# Altium schematic sheet DEBUGGER_R1.1.SchDoc
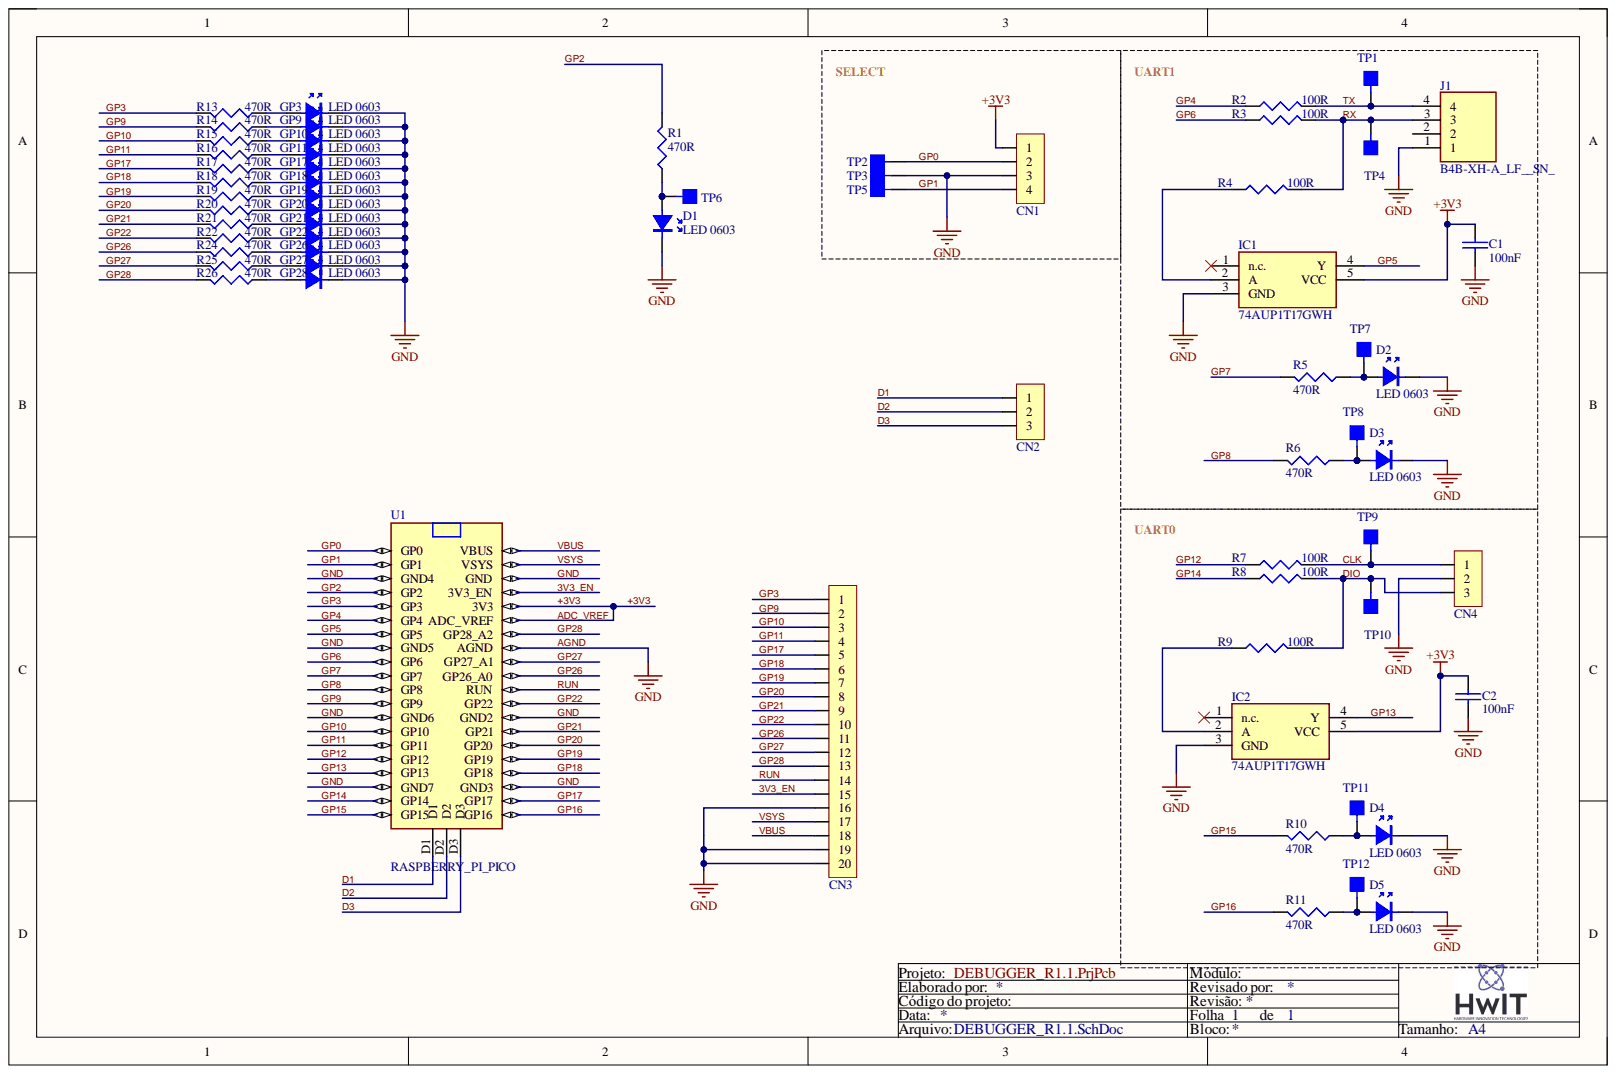
[diagram p0: DEBUGGER_R1.1.SchDoc]

## Parts
C1  100nF  [C0603]
C2  100nF  [C0603]
CN1  HD 1XV HOR  [HEADER4 VERT]  (value not drawn)
CN2  HD 1X3 HOR  [HEADER3 VERT]  (value not drawn)
CN3  HD 1X20 VERT  [HEADER 20 VERT 2.54mm]  (value not drawn)
CN4  HD 1X3 HOR  [HEADER3 VERT]  (value not drawn)
D1  LED 0603  [LED0603]
D2  LED 0603  [LED0603]
D3  LED 0603  [LED0603]
D4  LED 0603  [LED0603]
D5  LED 0603  [LED0603]
GP3  LED 0603  [LED0603]
GP9  LED 0603  [LED0603]
GP10  LED 0603  [LED0603]
GP11  LED 0603  [LED0603]
GP17  LED 0603  [LED0603]
GP18  LED 0603  [LED0603]
GP19  LED 0603  [LED0603]
GP20  LED 0603  [LED0603]
GP21  LED 0603  [LED0603]
GP22  LED 0603  [LED0603]
GP26  LED 0603  [LED0603]
GP27  LED 0603  [LED0603]
GP28  LED 0603  [LED0603]
IC1  74AUP1T17GWH  [SOT65P212X110-5N]
IC2  74AUP1T17GWH  [SOT65P212X110-5N]
J1  B4B-XH-A_LF__SN_  [B4BXHALFSN]
R1  470R  [R0603]
R2  100R  [R0603]
R3  100R  [R0603]
R4  100R  [R0603]
R5  470R  [R0603]
R6  470R  [R0603]
R7  100R  [R0603]
R8  100R  [R0603]
R9  100R  [R0603]
R10  470R  [R0603]
R11  470R  [R0603]
R13  470R  [R0603]
R14  470R  [R0603]
R15  470R  [R0603]
R16  470R  [R0603]
R17  470R  [R0603]
R18  470R  [R0603]
R19  470R  [R0603]
R20  470R  [R0603]
R21  470R  [R0603]
R22  470R  [R0603]
R24  470R  [R0603]
R25  470R  [R0603]
R26  470R  [R0603]
TP1  TP60  [TP60]  (value not drawn)  (no BOM)
TP2  TP60  [TP60]  (value not drawn)  (no BOM)
TP3  TP60  [TP60]  (value not drawn)  (no BOM)
TP4  TP60  [TP60]  (value not drawn)  (no BOM)
TP5  TP60  [TP60]  (value not drawn)  (no BOM)
TP6  TP60  [TP60]  (no BOM)
TP7  TP60  [TP60]  (value not drawn)  (no BOM)
TP8  TP60  [TP60]  (value not drawn)  (no BOM)
TP9  TP60  [TP60]  (value not drawn)  (no BOM)
TP10  TP60  [TP60]  (value not drawn)  (no BOM)
TP11  TP60  [TP60]  (value not drawn)  (no BOM)
TP12  TP60  [TP60]  (value not drawn)  (no BOM)
U1  RASPBERRY_PI_PICO  [SC0915_RPI]

## Nets
3V3_EN: C1.2 C2.2 CN1.1 CN3.15 IC1.5(VCC) IC2.5(VCC) U1.35(ADC_VREF) U1.36(3V3) U1.37(3V3_EN)  [also labelled +3V3]
AGND: C1.1 C2.1 CN1.3 CN3.16 CN3.19 CN3.20 CN4.2 D1.K D2.K D3.K D4.K D5.K GP3.K GP9.K GP10.K GP11.K GP17.K GP18.K GP19.K GP20.K GP21.K GP22.K GP26.K GP27.K GP28.K IC1.3(GND) IC2.3(GND) J1.1 TP3.1 U1.3(GND4) U1.8(GND5) U1.13(GND6) U1.18(GND7) U1.23(GND3) U1.28(GND2) U1.33(AGND) U1.38(GND)
CLK: CN4.1 R7.2 TP9.1
D1: CN2.1 U1.D1
D2: CN2.2 U1.D2
D3: CN2.3 U1.D3
DIO: CN4.3 R8.2 R9.2 TP10.1
GP0: CN1.2 TP2.1 U1.1(GP0)
GP1: CN1.4 TP5.1 U1.2(GP1)
GP2: R1.2 U1.4(GP2)
GP3: CN3.1 R13.2 U1.5(GP3)
GP4: R2.1 U1.6(GP4)
GP5: IC1.4(Y) U1.7(GP5)
GP6: R3.1 U1.9(GP6)
GP7: R5.2 U1.10(GP7)
GP8: R6.2 U1.11(GP8)
GP9: CN3.2 R14.2 U1.12(GP9)
GP10: CN3.3 R15.2 U1.14(GP10)
GP11: CN3.4 R16.2 U1.15(GP11)
GP12: R7.1 U1.16(GP12)
GP13: IC2.4(Y) U1.17(GP13)
GP14: R8.1 U1.19(GP14)
GP15: R10.2 U1.20(GP15)
GP16: R11.2 U1.21(GP16)
GP17: CN3.5 R17.2 U1.22(GP17)
GP18: CN3.6 R18.2 U1.24(GP18)
GP19: CN3.7 R19.2 U1.25(GP19)
GP20: CN3.8 R20.2 U1.26(GP20)
GP21: CN3.9 R21.2 U1.27(GP21)
GP22: CN3.10 R22.2 U1.29(GP22)
GP26: CN3.11 R24.2 U1.31(GP26_A0)
GP27: CN3.12 R25.2 U1.32(GP27_A1)
GP28: CN3.13 R26.2 U1.34(GP28_A2)
RUN: CN3.14 U1.30(RUN)
RX: J1.3 R3.2 R4.2 TP4.1
TX: J1.4 R2.2 TP1.1
VBUS: CN3.18 U1.40(VBUS)
VSYS: CN3.17 U1.39(VSYS)
NetD1_A: D1.A R1.1 TP6.1
NetD2_A: D2.A R5.1 TP7.1
NetD3_A: D3.A R6.1 TP8.1
NetD4_A: D4.A R10.1 TP11.1
NetD5_A: D5.A R11.1 TP12.1
NetGP3_A: GP3.A R13.1
NetGP9_A: GP9.A R14.1
NetGP10_A: GP10.A R15.1
NetGP11_A: GP11.A R16.1
NetGP17_A: GP17.A R17.1
NetGP18_A: GP18.A R18.1
NetGP19_A: GP19.A R19.1
NetGP20_A: GP20.A R20.1
NetGP21_A: GP21.A R21.1
NetGP22_A: GP22.A R22.1
NetGP26_A: GP26.A R24.1
NetGP27_A: GP27.A R25.1
NetGP28_A: GP28.A R26.1
NetIC1_2: IC1.2(A) R4.1
NetIC2_2: IC2.2(A) R9.1

Not connected: IC1 1(n.c.); IC2 1(n.c.); J1 2

## Library data of the parts
C1 C2 = __uF 0603: CAP CER 0.1UF 50V X7R 0603; Manufacturer: YAGEO; Manufacturer PN: CC0603ZRY5V9BB104; Component Kind: Standard; Digi-Key Part Number: 311-1343-6-ND; Library Name: Capacitores.SchLib; Mouser Part Number: 603-C0603ZRY5V9BB104
CN1 = HEADER 1x4 V 2.54MM: CONN HEADER 4POS VERT 2.5MM; Component Kind: Standard; Library Name: Conectores.SchLib
CN2 CN4 = HEADER 1x3 v 2.54MM: CONN HEADER 3POS VERT 2.54MM; Component Kind: Standard; Library Name: Conectores.SchLib
CN3 = HEADER 1x20 V 2.54MM: CONN HEADER 1x20 V 2.54MM; Component Kind: Standard; Library Name: Conectores.SchLib
D1 = LED 0603: LED RED CLEAR 0603 SMD; Manufacturer: Würth Elektronik; Manufacturer PN: 150060RS73220; Component Kind: Standard; Digi-Key Part Number: 732-11996-6-ND; Library Name: Diodos.SchLib
D2 D4 = LED 0603: LED GREEN CLEAR 0603 SMD; Manufacturer: Würth Elektronik; Manufacturer PN: 150060VS73220; Component Kind: Standard; Digi-Key Part Number: 732-11997-6-ND; Library Name: Diodos.SchLib
D3 D5 = LED 0603: LED YELLOW CLEAR 0603 SMD; Manufacturer: Würth Elektronik; Manufacturer PN: 150060YS73220; Component Kind: Standard; Digi-Key Part Number: 732-11998-6-ND; Library Name: Diodos.SchLib
GP3 GP9-GP11 GP17-GP22 GP26-GP28 = LED 0603: LED BLUE CLEAR 0603 SMD; Manufacturer: Würth Elektronik; Manufacturer PN: 150060BS75000; Component Kind: Standard; Digi-Key Part Number: 732-4966-6-ND; Library Name: Diodos.SchLib; Mouser Part Number: 710-150060BS75000
IC1 IC2 = 74AUP1T17GWH: IC BUF NON-INVERT 3.6V 5TSSOP; Manufacturer: Nexperia; Arrow Part Number: 74AUP1T17GWH; Arrow Price/Stock: https://www.arrow.com/en/products/74aup1t17gwh/nexperia?region=nac; Component Kind: Standard; Datasheet Link: https://assets.nexperia.com/documents/data-sheet/74AUP1T17.pdf; Height: 1.1mm; Manufacturer PN: 74AUP1T17GWH; Mouser Part Number: 771-74AUP1T17GWH; Mouser Price/Stock: https://www.mouser.co.uk/ProductDetail/Nexperia/74AUP1T17GWH?qs=W0yvOO0ixfEkWoP8%252BUBOfA%3D%3D
J1 = B4B-XH-A_LF__SN_: CONN HEADER VERT 4POS 2.5MM; Manufacturer: JST (JAPAN SOLDERLESS TERMINALS); Manufacturer PN: B4B-XH-A(LF)(SN); Arrow Part Number: B4B-XH-A(LF)(SN); Arrow Price/Stock: https://www.arrow.com/en/products/b4b-xh-a-lf-sn/jst-manufacturing?utm_currency=USD&region=europe; Component Kind: Standard; Datasheet Link: https://datasheet.lcsc.com/szlcsc/JST-Sales-America-B4B-XH-A-LF-SN_C144395.pdf; Height: 7mm; Mouser Part Number: 306-B4BXHALFSN; Mouser Price/Stock: https://www.mouser.co.uk/ProductDetail/JST-Commercial/B4B-XH-ALFSN?qs=cdbOS8ANM9CfC08d%252BywhFw%3D%3D
R1 R5 R6 R10 R11 R13-R22 R24-R26 = __ R 0603 __ %: RES 470 OHM 5% 1/10W 0603; Manufacturer: YAGEO; Manufacturer PN: RC0603JR-07470RL; Component Kind: Standard; Digi-Key Part Number: 311-470GRDKR-ND; Mouser Part Number: 603-RC0603JR-07470RL; Library Name: Resistores.SchLib
R2-R4 R7-R9 = __ R 0603 __ %: RES 100 OHM 1% 1/10W 0603; Manufacturer: YAGEO; Manufacturer PN: RC0603FR-07100RL; Component Kind: Standard; Digi-Key Part Number: 311-100HRCT-ND; Mouser Part Number: 603-RC0603FR-07100RL; Library Name: Resistores.SchLib
TP1-TP12 = TP60: Teste Point 60 mils; Library Name: Geral.SchLib
U1 = RASPBERRY_PI_PICO: Raspberry Pi Board, Arm Cortex-M0+; Core Architecture:Arm; Core Sub-Architecture:Cortex-M0+; Kit Contents:Raspberry Pi Pico Board; No. Of Bits:32Bit; Silicon Core Number:Rp2040; Silicon Manufacturer:Raspberry Pi |Raspberry-Pi RASPBERRY PI PICO; Check_prices: https://www.snapeda.com/parts/RASPBERRY%20PI%20PICO/Raspberry+Pi/view-part/?ref=eda; Component Kind: Standard; Library Name: SamacSys.SchLib; Manufacturer: Raspberry Pi; Manufacturer PN: RASPBERRY PI PICO; Package: None; SnapEDA_Link: https://www.snapeda.com/parts/RASPBERRY%20PI%20PICO/Raspberry+Pi/view-part/?ref=snap
